# Altium schematic sheet 5vOutputs.SchDoc(5vOutputs)
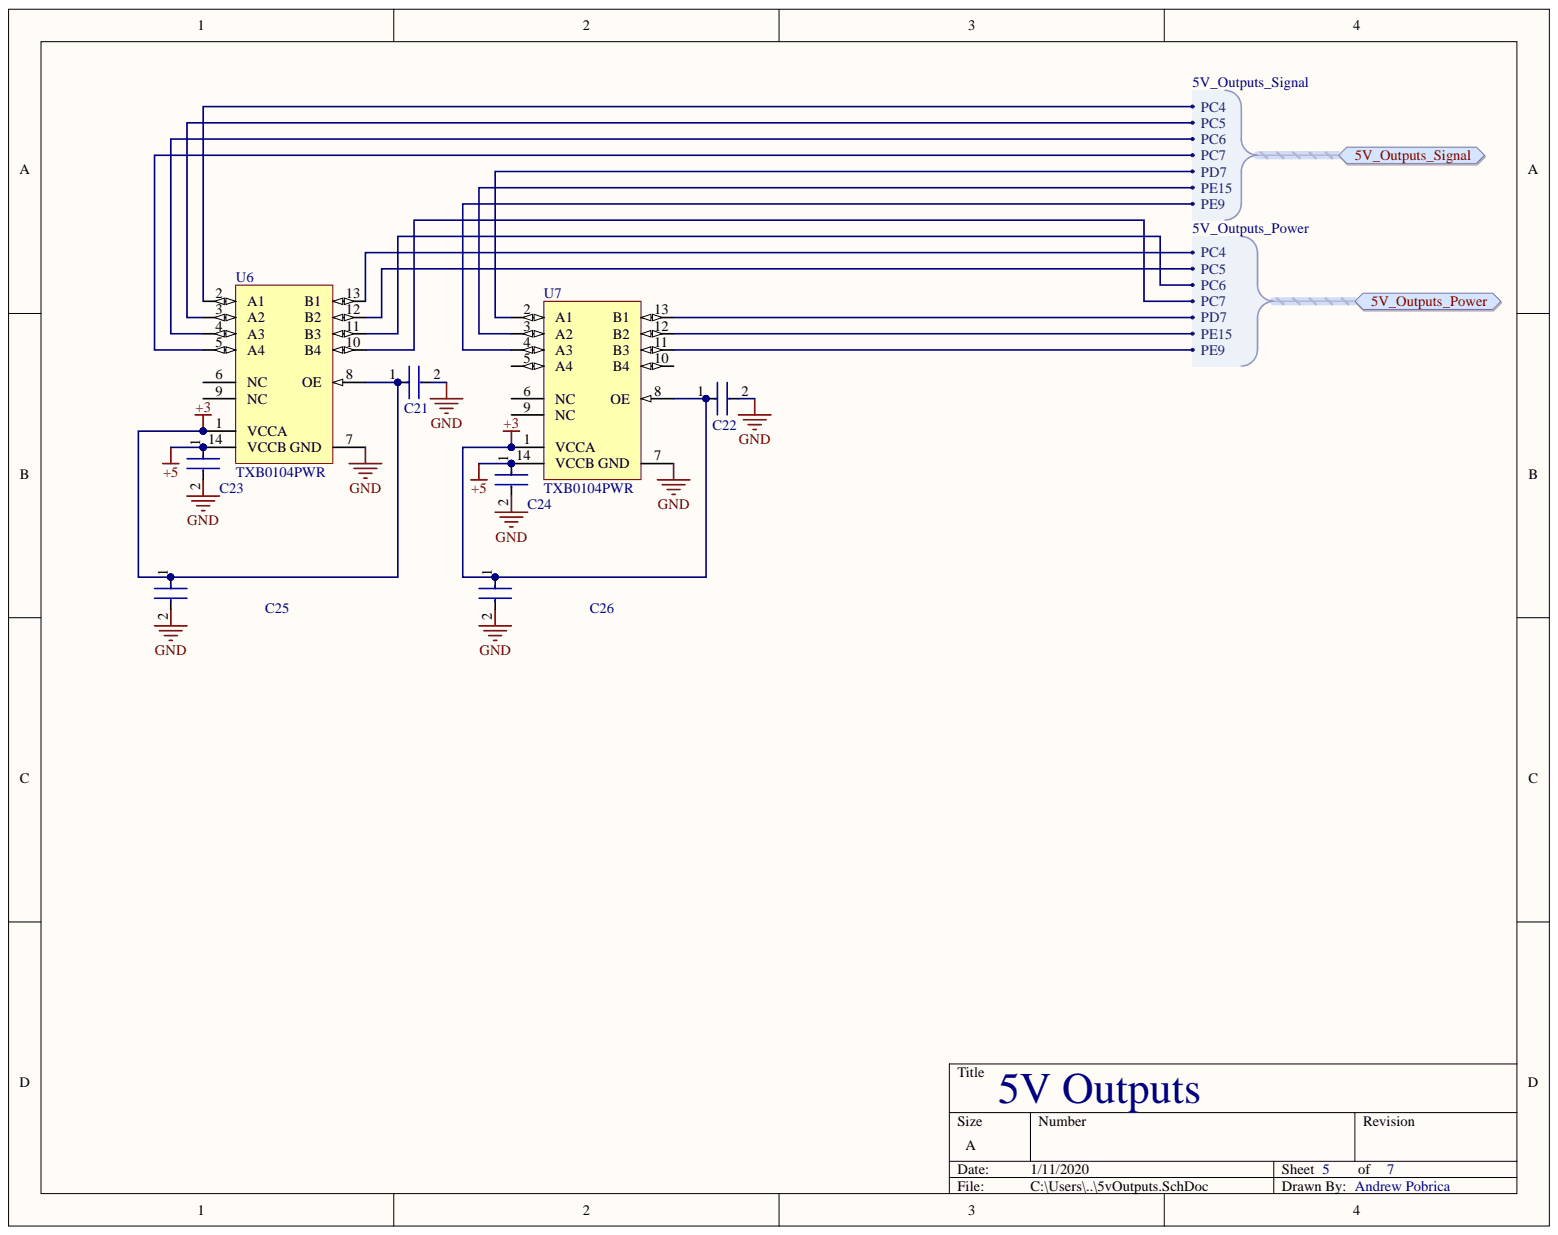
[diagram p0: 5vOutputs.SchDoc]

## Parts
C21  C0603C104K5RACTU  [Kemet_C0805_IPC_A]  (value not drawn)
C22  C0603C104K5RACTU  [Kemet_C0805_IPC_A]  (value not drawn)
C23  C0603C104K5RACTU  [Kemet_C0805_IPC_A]  (value not drawn)
C24  C0603C104K5RACTU  [Kemet_C0805_IPC_A]  (value not drawn)
C25  C0603C104K5RACTU  [Kemet_C0805_IPC_A]  (value not drawn)
C26  C0603C104K5RACTU  [Kemet_C0805_IPC_A]  (value not drawn)
U6  TXB0104PWR  [PW0014A_Custom]
U7  TXB0104PWR  [PW0014A_Custom]

## Ports
5V_Outputs_Power, 5V_Outputs_Power.PC4, 5V_Outputs_Power.PC5, 5V_Outputs_Power.PC6, 5V_Outputs_Power.PC7, 5V_Outputs_Power.PD7, 5V_Outputs_Power.PE9, 5V_Outputs_Power.PE15, 5V_Outputs_Signal, 5V_Outputs_Signal.PC4, 5V_Outputs_Signal.PC5, 5V_Outputs_Signal.PC6, 5V_Outputs_Signal.PC7, 5V_Outputs_Signal.PD7, 5V_Outputs_Signal.PE9, 5V_Outputs_Signal.PE15

## Nets
+3: C21.1 C22.1 C25.1 C26.1 U6.1(VCCA) U6.8(OE) U7.1(VCCA) U7.8(OE)
+5: C23.1 C24.1 U6.14(VCCB) U7.14(VCCB)
GND: C21.2 C22.2 C23.2 C24.2 C25.2 C26.2 U6.7(GND) U7.7(GND)
NetU6_2: U6.2(A1) ; port 5V_Outputs_Signal
NetU6_3: U6.3(A2) ; port 5V_Outputs_Signal
NetU6_4: U6.4(A3) ; port 5V_Outputs_Signal
NetU6_5: U6.5(A4) ; port 5V_Outputs_Signal
NetU6_10: U6.10(B4) ; port 5V_Outputs_Power
NetU6_11: U6.11(B3) ; port 5V_Outputs_Power
NetU6_12: U6.12(B2) ; port 5V_Outputs_Power
NetU6_13: U6.13(B1) ; port 5V_Outputs_Power
NetU7_2: U7.2(A1) ; port 5V_Outputs_Signal
NetU7_3: U7.3(A2) ; port 5V_Outputs_Signal
NetU7_4: U7.4(A3) ; port 5V_Outputs_Signal
NetU7_11: U7.11(B3) ; port 5V_Outputs_Power
NetU7_12: U7.12(B2) ; port 5V_Outputs_Power
NetU7_13: U7.13(B1) ; port 5V_Outputs_Power

Not connected: U6 6(NC) 9(NC); U7 5(A4) 6(NC) 9(NC) 10(B4)

## Library data of the parts
U6 U7 = TI-TXB0104-TSSOP_PW-14: 4-Bit Bidirectional Voltage-Level Translator with Automatic Direction Sensing and +/- 15-kV ESD Protection, -40 to 85 degC, 14-Pin TSSOP (PW), Green (RoHS & Sb/Br), Tape and Reel; Manufacturer: Texas Instruments; Voltage - Supply: 1.2V~3.6V,1.65V~5.5V; Propagation Delay (Max): 4ns; Product Training Modules: VoltageLevelTranslation; Output Type: Logic; Other Names: 296-21929-6; Number of Outputs/Channel: 1; Number of Channels: 4; Logic Function: Translator,Bidirectional; Dynamic Catalog: TXBSeries; Differential - Input: Output:No/No; Data Rate: 100Mbps; Supplier Device Package: 14-TSSOP; Standard Package: 1; Series: -; Packaging: Digi-Reel®; Package Reference: PW14; Package Description: 14-Pin Thin Shrink Small Outline Package, Body 5 x 4.4 mm, Pitch 0.65 mm; Package / Case: 14-TSSOP(0.173",4.40mmWidth); Operating Temperature: -40°C~85°C; Number of Bits: 4; Mounting Technology: SurfaceMount; Manufacturer URL: http://www.ti.com/; Library Name: Components - 19.05.19 - 16.35.SchLib; Input Type: Logic; Family: Logic-Translators; Datasheet Version: Rev. G, 11/2014; Datasheet URL: http://www.ti.com/general/docs/lit/getliterature.tsp?genericPartNumber=TXB0104&fileType=pdf; Component Kind: Standard; Category: IntegratedCircuits(ICs)
C21-C26 = C0603C104K5RACTU: Component Kind: Standard; Library Name: parts/UNK_Kemet_C0603C104K5RACTU_eec.SchLib
